# Altium schematic sheet pca10036_sheet6_STOP_central.SchDoc
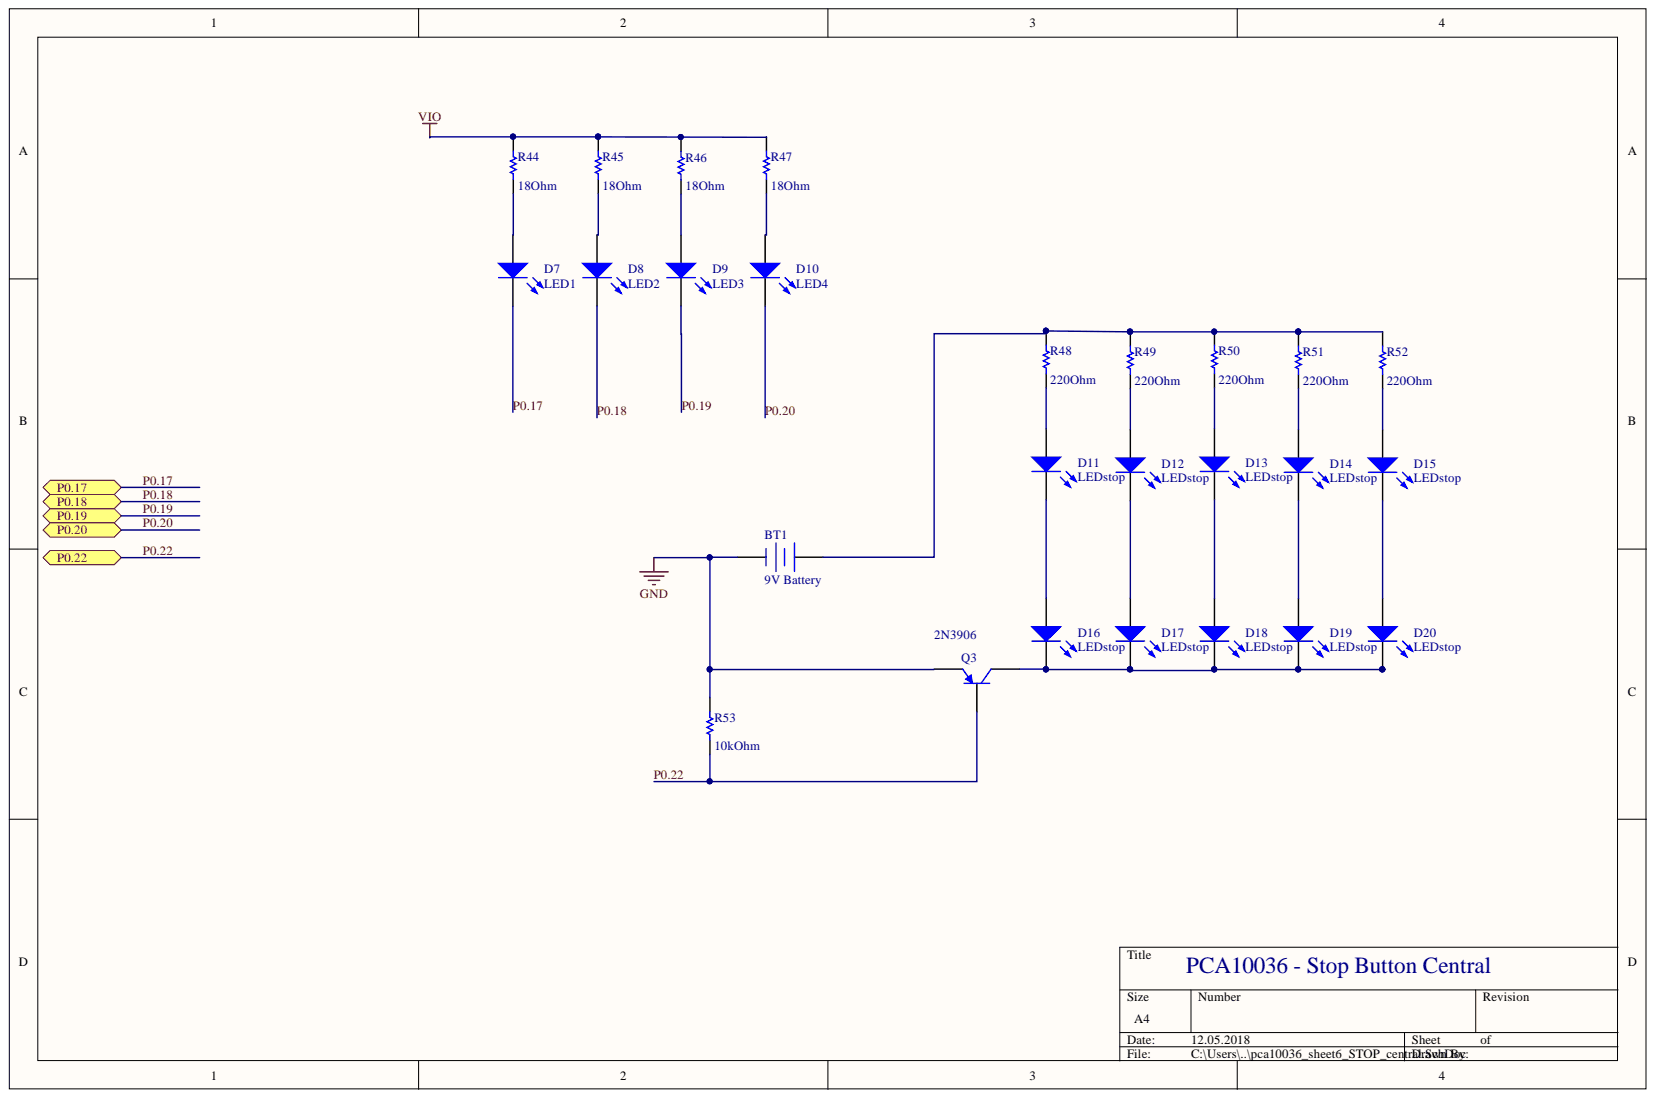
[diagram p0: pca10036_sheet6_STOP_central.SchDoc]

## Parts
BT1  9V Battery  [BAT-2]
D7  LED1  [LED-0]
D8  LED2  [LED-0]
D9  LED3  [LED-0]
D10  LED4  [LED-0]
D11  LEDstop  [LED-0]
D12  LEDstop  [LED-0]
D13  LEDstop  [LED-0]
D14  LEDstop  [LED-0]
D15  LEDstop  [LED-0]
D16  LEDstop  [LED-0]
D17  LEDstop  [LED-0]
D18  LEDstop  [LED-0]
D19  LEDstop  [LED-0]
D20  LEDstop  [LED-0]
Q3  2N3906  [TO-92A]
R44  value 18Ohm  [AXIAL-0.3]  (hidden comment: Res1)
R45  value 18Ohm  [AXIAL-0.3]  (hidden comment: Res1)
R46  value 18Ohm  [AXIAL-0.3]  (hidden comment: Res1)
R47  value 18Ohm  [AXIAL-0.3]  (hidden comment: Res1)
R48  value 220Ohm  [AXIAL-0.3]  (hidden comment: Res1)
R49  value 220Ohm  [AXIAL-0.3]  (hidden comment: Res1)
R50  value 220Ohm  [AXIAL-0.3]  (hidden comment: Res1)
R51  value 220Ohm  [AXIAL-0.3]  (hidden comment: Res1)
R52  value 220Ohm  [AXIAL-0.3]  (hidden comment: Res1)
R53  value 10kOhm  [AXIAL-0.3]  (hidden comment: Res1)

## Ports
P0.17, P0.18, P0.19, P0.20, P0.22

## Nets
GND: BT1.2 R53.1
VIO: R44.2 R45.2 R46.1 R47.2
P0.17: D7.2 ; port P0.17
P0.18: D8.2 ; port P0.18
P0.19: D9.2 ; port P0.19
P0.20: D10.2 ; port P0.20
P0.22: Q3.2 R53.2 ; port P0.22
NetBT1_1: BT1.1 R48.1 R49.1 R50.1 R51.1 R52.1
NetD7_1: D7.1 R44.1
NetD8_1: D8.1 R45.1
NetD9_1: D9.1 R46.2
NetD10_1: D10.1 R47.1
NetD11_1: D11.1 R48.2
NetD11_2: D11.2 D16.1
NetD12_1: D12.1 R49.2
NetD12_2: D12.2 D17.1
NetD13_1: D13.1 R50.2
NetD13_2: D13.2 D18.1
NetD14_1: D14.1 R51.2
NetD14_2: D14.2 D19.1
NetD15_1: D15.1 R52.2
NetD15_2: D15.2 D20.1
NetD16_2: D16.2 D17.2 D18.2 D19.2 D20.2 Q3.3

Not connected: Q3 1

## Library data of the parts
BT1 = Battery: Multicell Battery; Component Kind: Standard; LatestRevisionDate: 17-Jul-2002; LatestRevisionNote: Re-released for DXP Platform.; Library Name: Miscellaneous Devices.IntLib; PackageDescription: Battery; 2 Leads; PackageReference: BAT-2; Published: 24-Mar-1999; Publisher: Altium Limited
D7-D20 = LED0: Typical INFRARED GaAs LED; Component Kind: Standard; LatestRevisionDate: 08-Jul-2005; LatestRevisionNote: Mech Layer 1 removed.; Library Name: Miscellaneous Devices.IntLib; PackageDescription: LED; 2 Leads; PackageReference: LED-0; Published: 8-Jun-2000; Simulation: LED0; Publisher: Altium Limited
Q3 = 2N3906: PNP General Purpose Amplifier; Component Kind: Standard; ComponentLink1Description: Manufacturer Link; ComponentLink1URL: http://www.fairchildsemi.com/; ComponentLink2Description: Datasheet; ComponentLink2URL: http://www.fairchildsemi.com/ds/2N%2F2N3906.pdf; DatasheetVersion: 1997; LatestRevisionDate: 15-Jan-2003; LatestRevisionNote: PCB Footprint 'BCY-W3/D4.7' replaced; Library Name: Miscellaneous Devices.IntLib; PackageDescription: TO, Flat Index; 3 In-Line, Axial Leads; Body Dia. 4.5mm; Leads 0.51 x 0.48 mm (max); PackageReference: TO-92A; PackageVersion: Sep-1998; Published: 8-Jun-2000; Signal Integrity: 2N3906; Simulation: 2N3906; Publisher: Altium Limited
R44-R53 = Res1: Resistor; Component Kind: Standard; LatestRevisionDate: 17-Jul-2002; LatestRevisionNote: Re-released for DXP Platform.; Library Name: Miscellaneous Devices.IntLib; PackageDescription: Axial Device, Thru-Hole; 2 Leads; 0.3 in Pin Spacing; PackageReference: AXIAL-0.3; Published: 8-Jun-2000; Signal Integrity: Res; Simulation: RESISTOR; Publisher: Altium Limited
